# Altium schematic sheet MPPT.SchDoc
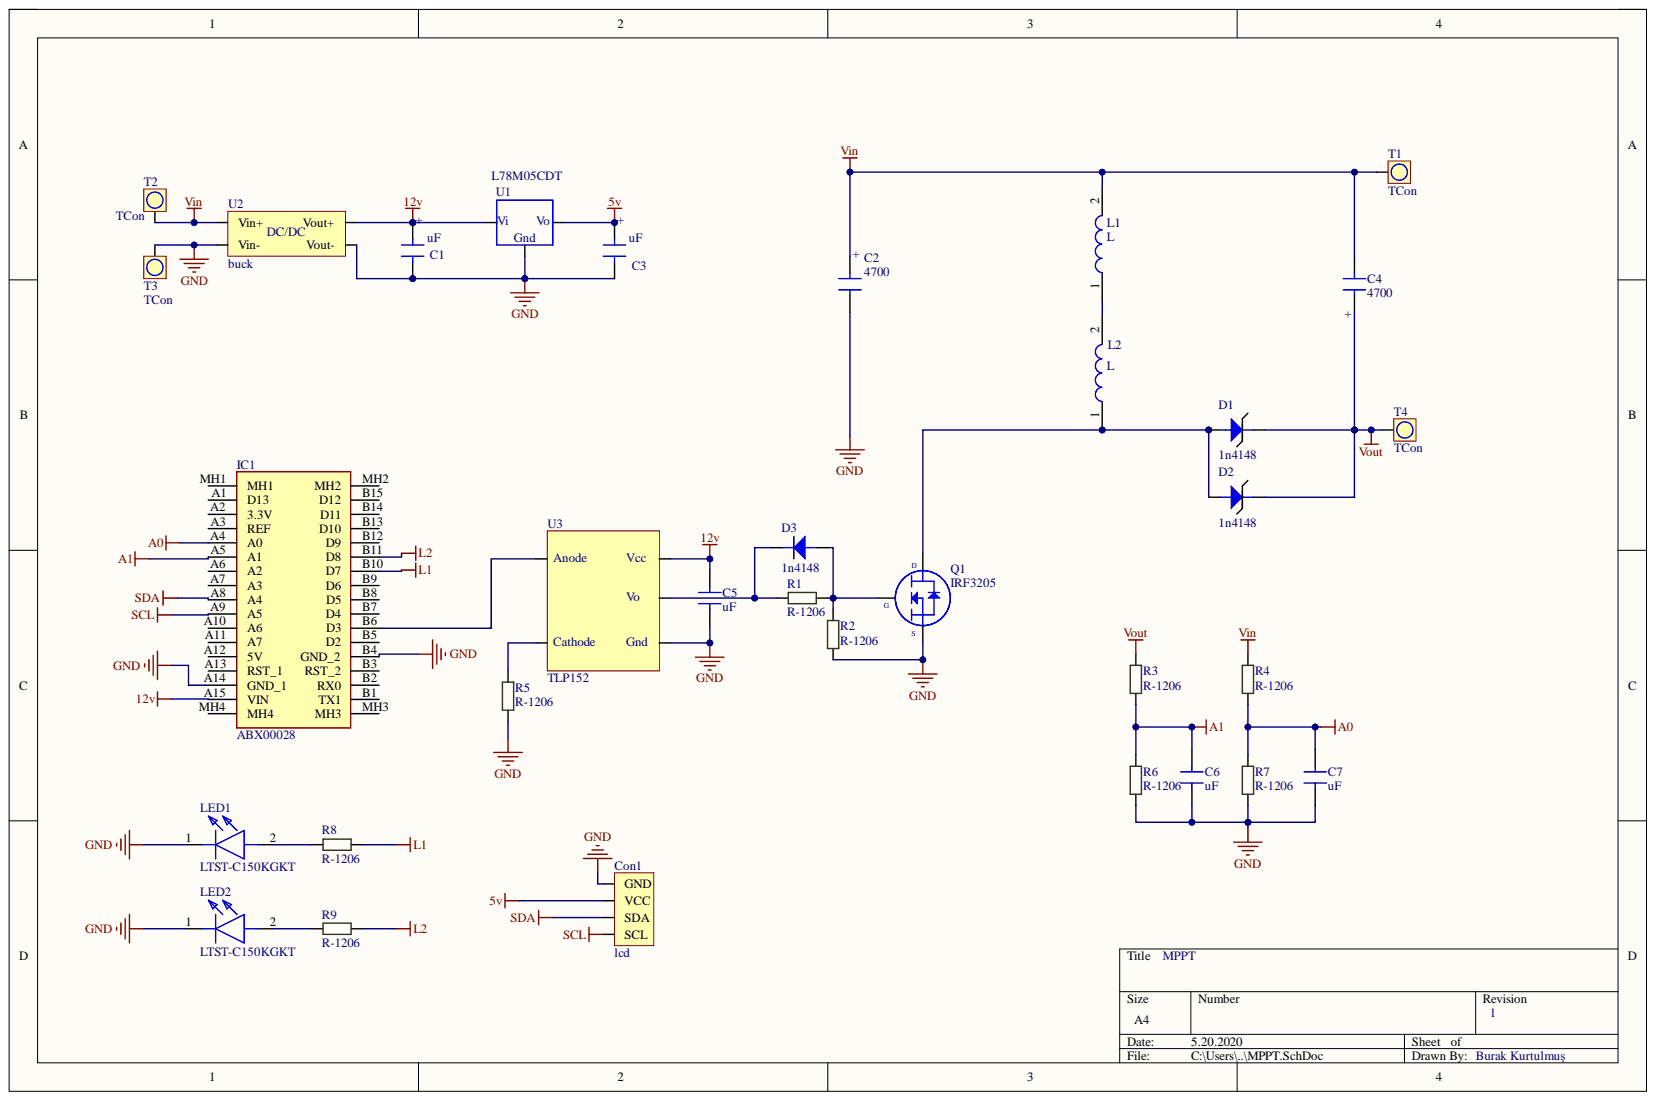
[diagram p0: MPPT.SchDoc]

## Parts
C1  uF  [CapSmdElc]
C2  4700  [Koshin 63v 4700 cap]
C3  uF  [CapSmdElc]
C4  4700  [Koshin 63v 4700 cap]
C5  uF  [C-1206W]
C6  uF  [C-1206W]
C7  uF  [C-1206W]
Con1  lcd  [lcd]
D1  1n4148  [TO254P483X1016X1994-3P]
D2  1n4148  [TO254P483X1016X1994-3P]
D3  1n4148  [SOD123]
IC1  ABX00028  [ABX00028]
L1  L  [L]
L2  L  [L]
LED1  LTST-C150KGKT  [L-1206]
LED2  LTST-C150KGKT  [L-1206]
Q1  IRF3205  [DPAK_TO263]
R1  R-1206  [R-1206W]
R2  R-1206  [R-1206W]
R3  R-1206  [R-1206W]
R4  R-1206  [R-1206W]
R5  R-1206  [R-1206W]
R6  R-1206  [R-1206W]
R7  R-1206  [R-1206W]
R8  R-1206  [R-1206W]
R9  R-1206  [R-1206W]
T1  TCon  [2pinCon]
T2  TCon  [2pinCon]
T3  TCon  [2pinCon]
T4  TCon  [2pinCon]
U1  L78M05CDT  [TO-252]
U2  buck  [DC_BOOST]
U3  TLP152  [TLP152]

## Nets
5v: C3.1 Con1.2(VCC) U1.3
12v: C1.1 C5.2 IC1.A15(VIN) U1.1 U2.4(Vout+) U3.5(Vcc)
A0: C7.2 IC1.A4(A0) R4.1 R7.2
A1: C6.2 IC1.A5(A1) R3.2 R6.2
GND: C1.2 C2.1 C3.2 C5.1 C6.1 C7.1 Con1.1(GND) IC1.A14(GND_1) IC1.B4(GND_2) LED1.1 LED2.1 Q1.2 R2.1 R5.1 R6.1 R7.1 T3.1 U1.4 U2.2(Vin-) U2.3(Vout-) U3.3(Gnd)
L1: IC1.B10(D7) R8.2
L2: IC1.B11(D8) R9.2
SCL: Con1.4(SCL) IC1.A9(A5)
SDA: Con1.3(SDA) IC1.A8(A4)
Vin: C2.2 C4.1 L1.2 R4.2 T1.1 T2.1 U2.1(Vin+)
Vout: C4.2 D1.1 D2.1 R3.1 T4.1
NetD1_2: D1.2 D2.2 L2.1 Q1.3
NetD3_a: D3.a Q1.1 R1.2 R2.2
NetD3_k: D3.k R1.1 U3.4(Vo)
NetL1_1: L1.1 L2.2
NetLED1_2: LED1.2 R8.1
NetLED2_2: LED2.2 R9.1
NetR5_2: R5.2 U3.2(Cathode)
NetU3_1: IC1.B6(D3) U3.1(Anode)

Not connected: IC1 A1(D13) A2(3.3V) A3(REF) A6(A2) A7(A3) A10(A6) A11(A7) A12(5V) A13(RST_1) B1(TX1) B2(RX0) B3(RST_2) B5(D2) B7(D4) B8(D5) B9(D6) B12(D9) B13(D10) B14(D11) B15(D12) MH1 MH2 MH3 MH4

## Library data of the parts
C1 C3 = C-10uf: Component Kind: Standard; Library Name: MPPT.SchLib
C5-C7 = C-1206: Component Kind: Standard; Library Name: MPPT.SchLib
D1 D2 = schotky: Diode Small Signal Switching 100V 0.15A 2-Pin SOD-123 T/R; Manufacturer: Vishay; Manufacturer Part Number: 1N4148W-E3-18; Average Rectified Current: 150mA; Element Configuration: Single; Forward Voltage: 1.2V; Max Power Dissipation: 350mW; Max Reverse Leakage Current: 5uA; Max Reverse Voltage (DC): 75V; Max Surge Current: 2A; Peak Reverse Current: 100uA; Recovery Time: 4ns; Component Kind: Standard; ComponentLink1Description: https://datasheet.ciiva.com/29885/1n4148w-29885941.pdf?src-supplier=Avnet; ComponentLink1URL: https://datasheet.ciiva.com/29885/1n4148w-29885941.pdf?src-supplier=Avnet; ComponentLink2Description: https://datasheet.ciiva.com/29593/1n4148w-29593113.pdf?src-supplier=Digi-Key; ComponentLink2URL: https://datasheet.ciiva.com/29593/1n4148w-29593113.pdf?src-supplier=Digi-Key; ComponentLink3Description: https://datasheet.ciiva.com/29593/1n4148w-29593111.pdf?src-supplier=Digi-Key; ComponentLink3URL: https://datasheet.ciiva.com/29593/1n4148w-29593111.pdf?src-supplier=Digi-Key; ComponentLink4Description: https://datasheet.ciiva.com/29593/1n4148w-29593112.pdf?src-supplier=Digi-Key; ComponentLink4URL: https://datasheet.ciiva.com/29593/1n4148w-29593112.pdf?src-supplier=Digi-Key; Contact Plating: Tin; Forward Current: 150mA; Height: 1.25mm; Lead Free: Lead Free; Length: 2.85mm; Max Operating Temperature: 150°C; Max Repetitive Reverse Voltage (Vrrm): 100V; Min Operating Temperature: -55°C; Mount: Surface Mount; Number of Pins: 2; Package Quantity: 10000; Packaging: Tape and Reel; Peak Non-Repetitive Surge Current: 2A; Power Dissipation: 350mW; Pricing 1: 1 = 0.03900, 25 = 0.03800, 100 = 0.03600, 250 = 0.03400, 500 = 0.03200, 1000 = 0.03100, 2500 = 0.02900 (USD); Radiation Hardening: No; Reverse Recovery Time: 4ns; RoHS: Yes; Stock 1: 6838; Supplier 1: Allied Electronics; Supplier Part Number 1: 70061597; Weight: 0.000363oz; Width: 1.7mm; Library Name: MPPT.SchLib
D3 = 1N5819w: Diode Small Signal Switching 100V 0.15A 2-Pin SOD-123 T/R; Manufacturer: Vishay; Manufacturer Part Number: 1N4148W-E3-18; Average Rectified Current: 150mA; Element Configuration: Single; Forward Voltage: 1.2V; Max Power Dissipation: 350mW; Max Reverse Leakage Current: 5uA; Max Reverse Voltage (DC): 75V; Max Surge Current: 2A; Peak Reverse Current: 100uA; Recovery Time: 4ns; Component Kind: Standard; ComponentLink1Description: https://datasheet.ciiva.com/29885/1n4148w-29885941.pdf?src-supplier=Avnet; ComponentLink1URL: https://datasheet.ciiva.com/29885/1n4148w-29885941.pdf?src-supplier=Avnet; ComponentLink2Description: https://datasheet.ciiva.com/29593/1n4148w-29593113.pdf?src-supplier=Digi-Key; ComponentLink2URL: https://datasheet.ciiva.com/29593/1n4148w-29593113.pdf?src-supplier=Digi-Key; ComponentLink3Description: https://datasheet.ciiva.com/29593/1n4148w-29593111.pdf?src-supplier=Digi-Key; ComponentLink3URL: https://datasheet.ciiva.com/29593/1n4148w-29593111.pdf?src-supplier=Digi-Key; ComponentLink4Description: https://datasheet.ciiva.com/29593/1n4148w-29593112.pdf?src-supplier=Digi-Key; ComponentLink4URL: https://datasheet.ciiva.com/29593/1n4148w-29593112.pdf?src-supplier=Digi-Key; Contact Plating: Tin; Forward Current: 150mA; Height: 1.25mm; Lead Free: Lead Free; Length: 2.85mm; Max Operating Temperature: 150°C; Max Repetitive Reverse Voltage (Vrrm): 100V; Min Operating Temperature: -55°C; Mount: Surface Mount; Number of Pins: 2; Package Quantity: 10000; Packaging: Tape and Reel; Peak Non-Repetitive Surge Current: 2A; Power Dissipation: 350mW; Pricing 1: 1 = 0.03900, 25 = 0.03800, 100 = 0.03600, 250 = 0.03400, 500 = 0.03200, 1000 = 0.03100, 2500 = 0.02900 (USD); Radiation Hardening: No; Reverse Recovery Time: 4ns; RoHS: Yes; Stock 1: 6838; Supplier 1: Allied Electronics; Supplier Part Number 1: 70061597; Weight: 0.000363oz; Width: 1.7mm; Library Name: MPPT.SchLib
IC1 = ABX00028: Integrated Circuit; Manufacturer_Name: Arduino; Component Kind: Standard; Datasheet Link: https://store.arduino.cc/arduino-nano-every; Height: 10mm; Manufacturer_Part_Number: ABX00028; Mouser Part Number: 782-ABX00028; Mouser Price/Stock: https://www.mouser.co.uk/ProductDetail/Arduino/ABX00028?qs=PzGy0jfpSMtit6rnFl8mVg%3D%3D; RS Part Number: 1927586; RS Price/Stock: http://uk.rs-online.com/web/p/products/1927586; Library Name: MPPT.SchLib
L1 L2 = L: Inductor; Manufacturer_Name: ABRACON; Manufacturer_Part_Number: ATFC-0201-10N-G-T; Component Kind: Standard; Datasheet Link: http://www.abracon.com/Magnetics/new/ATFC-0201.pdf; Height: 0.28mm; Mouser Part Number: 815-ATFC-0201-10NG; Mouser Price/Stock: https://www.mouser.com/Search/Refine.aspx?Keyword=815-ATFC-0201-10NG; Library Name: MPPT.SchLib
LED1 LED2 = LTST-C150KGKT: LED; Manufacturer_Name: Lite-On; Component Kind: Standard; Datasheet Link: http://optoelectronics.liteon.com/upload/download/ds22-2000-072/ltst-c150kgkt.pdf; Height: 1.2mm; Manufacturer_Part_Number: LTST-C150KGKT; Mouser Part Number: 859-LTST-C150KGKT; Mouser Price/Stock: https://www.mouser.com/Search/Refine.aspx?Keyword=859-LTST-C150KGKT; RS Part Number: 6920871; RS Price/Stock: https://uk.rs-online.com/web/p/products/6920871; Library Name: MPPT.SchLib
Q1 = IRF3205STRLPBF: MOSFET N-CH 55V 110A D2PAK; Manufacturer: Infineon; Drain to Source Resistance: 8mR; Gate to Source Voltage (Vgs): 20V; Max Power Dissipation: 200W; Threshold Voltage: 4V; Turn-Off Delay Time: 50ns; Turn-On Delay Time: 14ns; Input Capacitance: 3.247nF; Case/Package: D2PAK; Component Kind: Standard; ComponentLink1Description: https://www.alliedelec.com/m/d/b8827ca43655240244f0cc5c2198e6b1.pdf?src-supplier=Allied+Electronics; ComponentLink1URL: https://www.alliedelec.com/m/d/b8827ca43655240244f0cc5c2198e6b1.pdf?src-supplier=Allied+Electronics; ComponentLink2Description: https://www.infineon.com/dgdl/irf3205spbf.pdf?fileId=5546d462533600a4015355defac9190c&src-supplier=Digi-Key; ComponentLink2URL: https://www.infineon.com/dgdl/irf3205spbf.pdf?fileId=5546d462533600a4015355defac9190c&src-supplier=Digi-Key; ComponentLink3Description: http://www.infineon.com/dgdl/irf3205spbf.pdf?fileId=5546d462533600a4015355defac9190c&src-supplier=Digi-Key; ComponentLink3URL: http://www.infineon.com/dgdl/irf3205spbf.pdf?fileId=5546d462533600a4015355defac9190c&src-supplier=Digi-Key; ComponentLink4Description: http://www.farnell.com/datasheets/1884183.pdf?src-supplier=Element14; ComponentLink4URL: http://www.farnell.com/datasheets/1884183.pdf?src-supplier=Element14; Continuous Drain Current (ID): 110A; Drain to Source Breakdown Voltage: 55V; Drain to Source Voltage (Vdss): 55V; Height: 0.19inch; Lead Free: Lead Free; Length: 0.42inch; Manufacturer Part Number: IRF3205STRLPBF; Max Operating Temperature: 175°C; Min Operating Temperature: -55°C; Mount: Surface Mount; Number of Pins: 3; Packaging: Cut Tape; Power Dissipation: 200W; Pricing 1: 1 = 1,84000, 10 = 1,66600, 100 = 1,33840 (USD); Rds On Max: 8mR; REACH SVHC: No SVHC; RoHS: Yes; Stock 1: 3281; Supplier 1: Digi-Key; Supplier Part Number 1: IRF3205STRLPBFCT-ND; Width: 0.38inch; Library Name: MPPT.SchLib
R1-R9 = R-1206: Res1206; Component Kind: Standard; Library Name: MPPT.SchLib
T1-T4 = Terminal Connector: Component Kind: Standard; ComponentLink1Description: https://www.ozdisan.com/konnektor-baglanti-elemanlari/terminal-konnektorler/vida-tipi-konnektorler/K36-00AH; ComponentLink1URL: https://www.ozdisan.com/konnektor-baglanti-elemanlari/terminal-konnektorler/vida-tipi-konnektorler/K36-00AH; Library Name: MPPT.SchLib
U1 = L78M15CDT: Linear Voltage Regulators 15V 0.5A Positive; Manufacturer: STMicroelectronics; Dropout Voltage: 2V; Max Output Current: 500mA; Max Input Voltage: 35V; Number of Outputs: 1; Output Type: Fixed; Quiescent Current: 6mA; Case/Package: DPAK; Component Kind: Standard; ComponentLink1Description: http://docs-usa.electrocomponents.com/techlib:/itc_doclist_US.htm?stockno=1890999&src-supplier=Allied+Electronics; ComponentLink1URL: http://docs-usa.electrocomponents.com/techlib:/itc_doclist_US.htm?stockno=1890999&src-supplier=Allied+Electronics; ComponentLink2Description: http://www.st.com/web/en/resource/technical/document/datasheet/CD00000447.pdf?src-supplier=Avnet+Europe; ComponentLink2URL: http://www.st.com/web/en/resource/technical/document/datasheet/CD00000447.pdf?src-supplier=Avnet+Europe; ComponentLink3Description: http://www.mouser.com/ds/2/389/stmicroelectronics_cd00000447-329548.pdf?src-supplier=Mouser; ComponentLink3URL: http://www.mouser.com/ds/2/389/stmicroelectronics_cd00000447-329548.pdf?src-supplier=Mouser; Height: 2.4mm; Length: 6.6mm; Manufacturer Part Number: L78M15CDT; Max Operating Temperature: 150°C; Min Operating Temperature: 0°C; Min Input Voltage: 35V; Mount: Surface Mount; Number of Pins: 3; Output Current: 500mA; Output Voltage: 15V; Package Quantity: 75; Packaging: Rail/Tube; Polarity: Positive; Radiation Hardening: No; RoHS: Yes; Supplier 1: Avnet; Supplier Part Number 1: L78M15CDT; Width: 6.2mm; Library Name: MPPT.SchLib
U3 = TLP152(TPL,E: OPTOISO 3.75KV GATE DRIVER 6SO-5; Manufacturer: Toshiba; Direction: Unidirectional; Fall Time: 22ns; Forward Current: 10mA; Forward Voltage: 1.57V; High Level Output Current: 2A; Max Output Current: 2.5A; Max Power Dissipation: 260mW; Number of Channels: 1; Propagation Delay: 165ns; Pulse Width Distortion (PWD): 50ns; Rise Time: 18ns; Turn-On Delay Time: 190ns; Case/Package: SO; Component Kind: Standard; ComponentLink1Description: https://datasheet.ciiva.com/41848/tlp152-datasheet-en-20120914-41848928.pdf?src-supplier=Digi-Key; ComponentLink1URL: https://datasheet.ciiva.com/41848/tlp152-datasheet-en-20120914-41848928.pdf?src-supplier=Digi-Key; Low Level Output Current: 2A; Manufacturer Part Number: TLP152(TPL,E; Max Operating Temperature: 100°C; Min Operating Temperature: -40°C; Mount: Surface Mount; Number of Pins: 5; Output Current: 2.5A; Package Quantity: 3000; Packaging: Tape and Reel; Pricing 1: 1 = 1.55000, 10 = 0.96800, 50 = 0.96800, 100 = 0.87200, 1000 = 0.54300, 10000 = 0.44000 (USD); RoHS: Yes; Stock 1: 22321; Supplier 1: Mouser; Supplier Part Number 1: 757-TLP152TPLE; Library Name: MPPT.SchLib
C2 C4 = 4700: Component Kind: Standard; Library Name: MPPT.SchLib
Con1 = lcd: Component Kind: Standard; Library Name: MPPT.SchLib
U2 = buck: Component Kind: Standard; Library Name: MPPT.SchLib
